# Altium schematic sheet Schematic.SchDoc(Schematic)
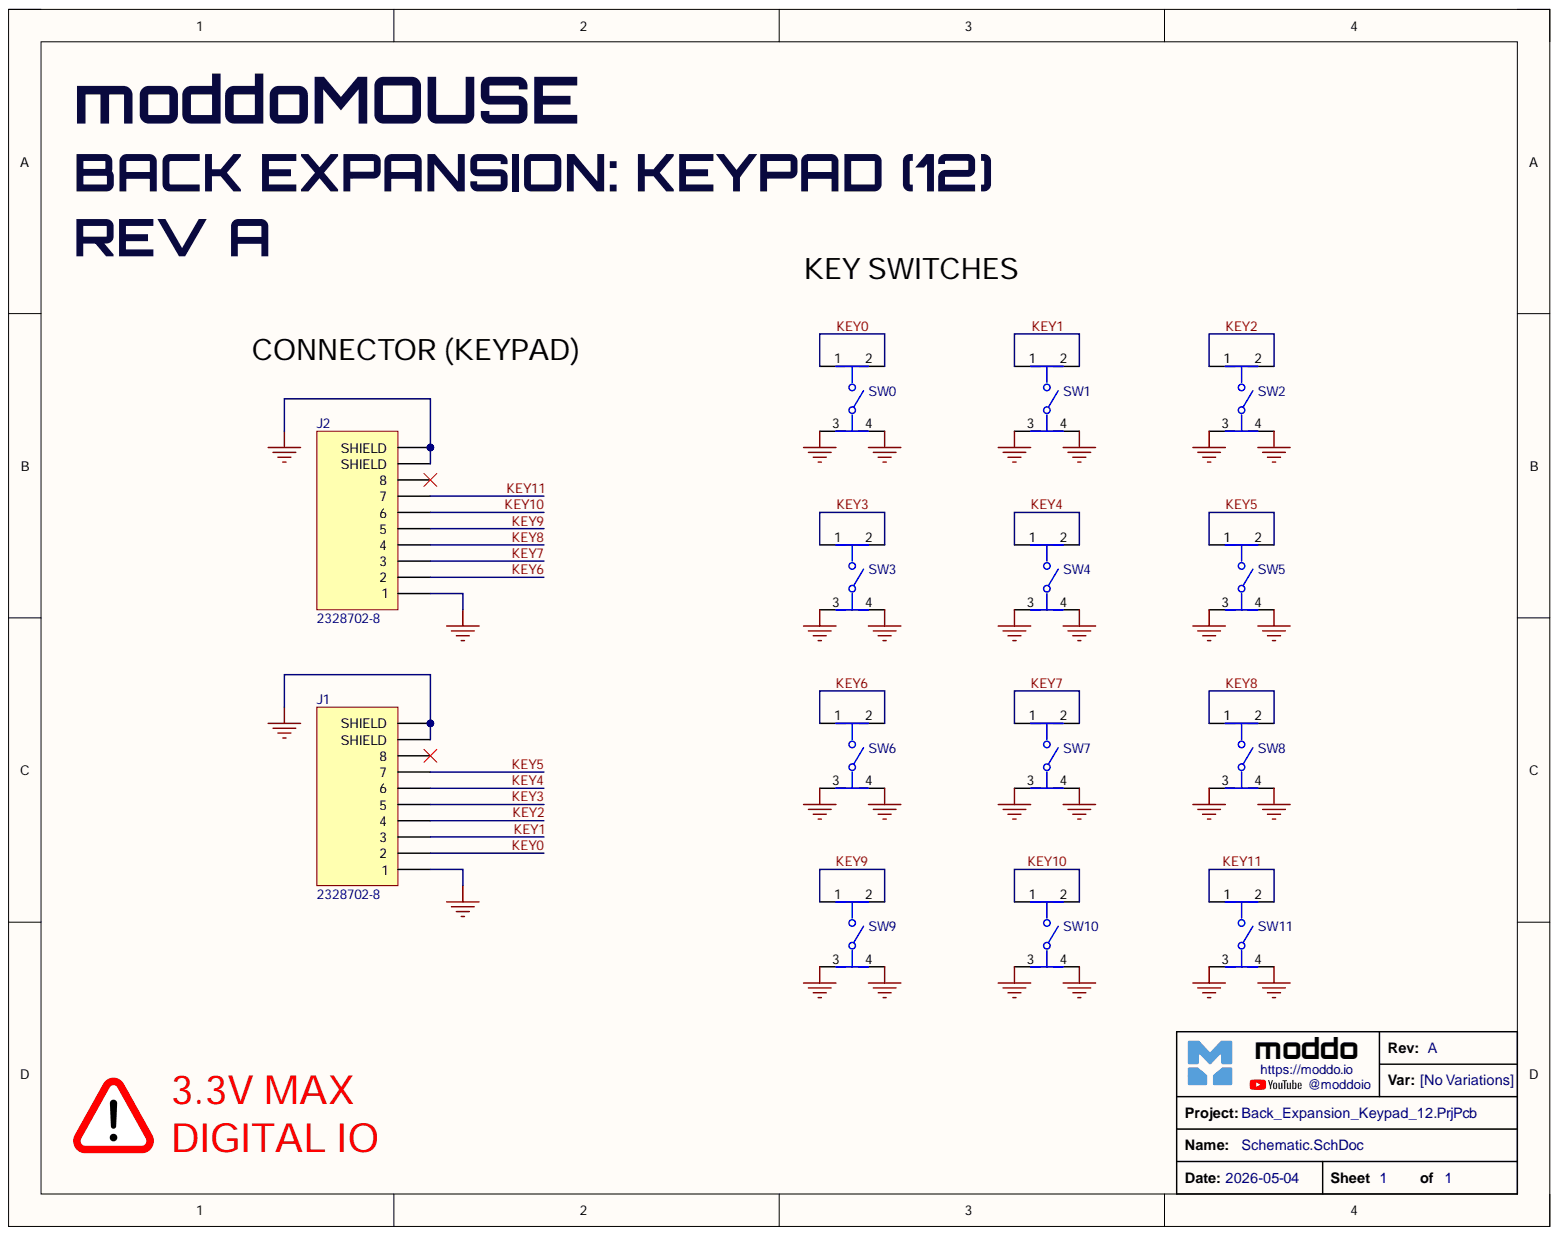
[diagram p0: Schematic.SchDoc]

## Parts
J1  2328702-8  [2328702-8]
J2  2328702-8  [2328702-8]
SW0  GT-TS090C-H031-L5  [Switch_6_2mm_6_2mm_3_1mm]  (value not drawn)
SW1  GT-TS090C-H031-L5  [Switch_6_2mm_6_2mm_3_1mm]  (value not drawn)
SW2  GT-TS090C-H031-L5  [Switch_6_2mm_6_2mm_3_1mm]  (value not drawn)
SW3  GT-TS090C-H031-L5  [Switch_6_2mm_6_2mm_3_1mm]  (value not drawn)
SW4  GT-TS090C-H031-L5  [Switch_6_2mm_6_2mm_3_1mm]  (value not drawn)
SW5  GT-TS090C-H031-L5  [Switch_6_2mm_6_2mm_3_1mm]  (value not drawn)
SW6  GT-TS090C-H031-L5  [Switch_6_2mm_6_2mm_3_1mm]  (value not drawn)
SW7  GT-TS090C-H031-L5  [Switch_6_2mm_6_2mm_3_1mm]  (value not drawn)
SW8  GT-TS090C-H031-L5  [Switch_6_2mm_6_2mm_3_1mm]  (value not drawn)
SW9  GT-TS090C-H031-L5  [Switch_6_2mm_6_2mm_3_1mm]  (value not drawn)
SW10  GT-TS090C-H031-L5  [Switch_6_2mm_6_2mm_3_1mm]  (value not drawn)
SW11  GT-TS090C-H031-L5  [Switch_6_2mm_6_2mm_3_1mm]  (value not drawn)

## Nets
GND: J1.1 J1.S1(SHIELD) J1.S2(SHIELD) J2.1 J2.S1(SHIELD) J2.S2(SHIELD) SW0.3 SW0.4 SW1.3 SW1.4 SW2.3 SW2.4 SW3.3 SW3.4 SW4.3 SW4.4 SW5.3 SW5.4 SW6.3 SW6.4 SW7.3 SW7.4 SW8.3 SW8.4 SW9.3 SW9.4 SW10.3 SW10.4 SW11.3 SW11.4
KEY0: J1.2 SW0.1 SW0.2
KEY1: J1.3 SW1.1 SW1.2
KEY2: J1.4 SW2.1 SW2.2
KEY3: J1.5 SW3.1 SW3.2
KEY4: J1.6 SW4.1 SW4.2
KEY5: J1.7 SW5.1 SW5.2
KEY6: J2.2 SW6.1 SW6.2
KEY7: J2.3 SW7.1 SW7.2
KEY8: J2.4 SW8.1 SW8.2
KEY9: J2.5 SW9.1 SW9.2
KEY10: J2.6 SW10.1 SW10.2
KEY11: J2.7 SW11.1 SW11.2

Not connected: J1 8; J2 8

## Library data of the parts
J1 J2 = 2328702-8: FFC/FPC Connector, 8 Contact(s), 1 Row(s), Right Angle, Solder Terminal, Natural Insulator; Manufacturer: TE Connectivity; Actuator Color: Black; Actuator Material: Thermoplastic; Connector System: Wire-to-Board; Gender: Receptacle; Number of Contacts: 8; Operating Supply Voltage: 50V; Plating: Gold; Sealable: No (Without); Category: FFC / FPC; Component Kind: Standard; ComponentLink1Description: https://www.te.com/commerce/DocumentDelivery/DDEController?Action=srchrtrv&amp;DocNm=2328702&amp;DocType=Customer+Drawing&amp;DocLang=English&src-supplier=Digikey; ComponentLink1URL: https://www.te.com/commerce/DocumentDelivery/DDEController?Action=srchrtrv&amp;DocNm=2328702&amp;DocType=Customer+Drawing&amp;DocLang=English&src-supplier=Digikey; Contact Material: Copper Alloy; Contact Plating: Gold; Flammability Rating: UL94 V-0; Height: 1mm; Housing Color: Natural; Housing Material: Thermoplastic; Length: 6mm; Library Name: Connectors.SchLib; Manufacturer Part Number: 2328702-8; Max Operating Temperature: 85°C; Min Operating Temperature: -40°C; Mounting Technology: Surface Mount; Number of Positions: 8; Number of Rows: 1; Orientation: Right Angle; Packaging: Cut Tape; Pricing 1: 28000=0.0961 (USD); RoHS: Yes; Series: -; Stock 1: 56000; Supplier 1: Arrow Electronics; Supplier Part Number 1: 2328702-8; Termination: Solder; Voltage Rating: 50V
SW0-SW11 = GT-TS090C-H031-L5: Tactile Switch SPST 100gf 3.1mm SMD (SMT) Tab 6.2mm x 6.2mm Surface Mount; Manufacturer: G-Switch; Component Kind: Standard; Library Name: Others.SchLib; Manufacturer Part Number: GT-TS090C-H031-L5
